# Altium schematic sheet BodySoftSnake.SchDoc
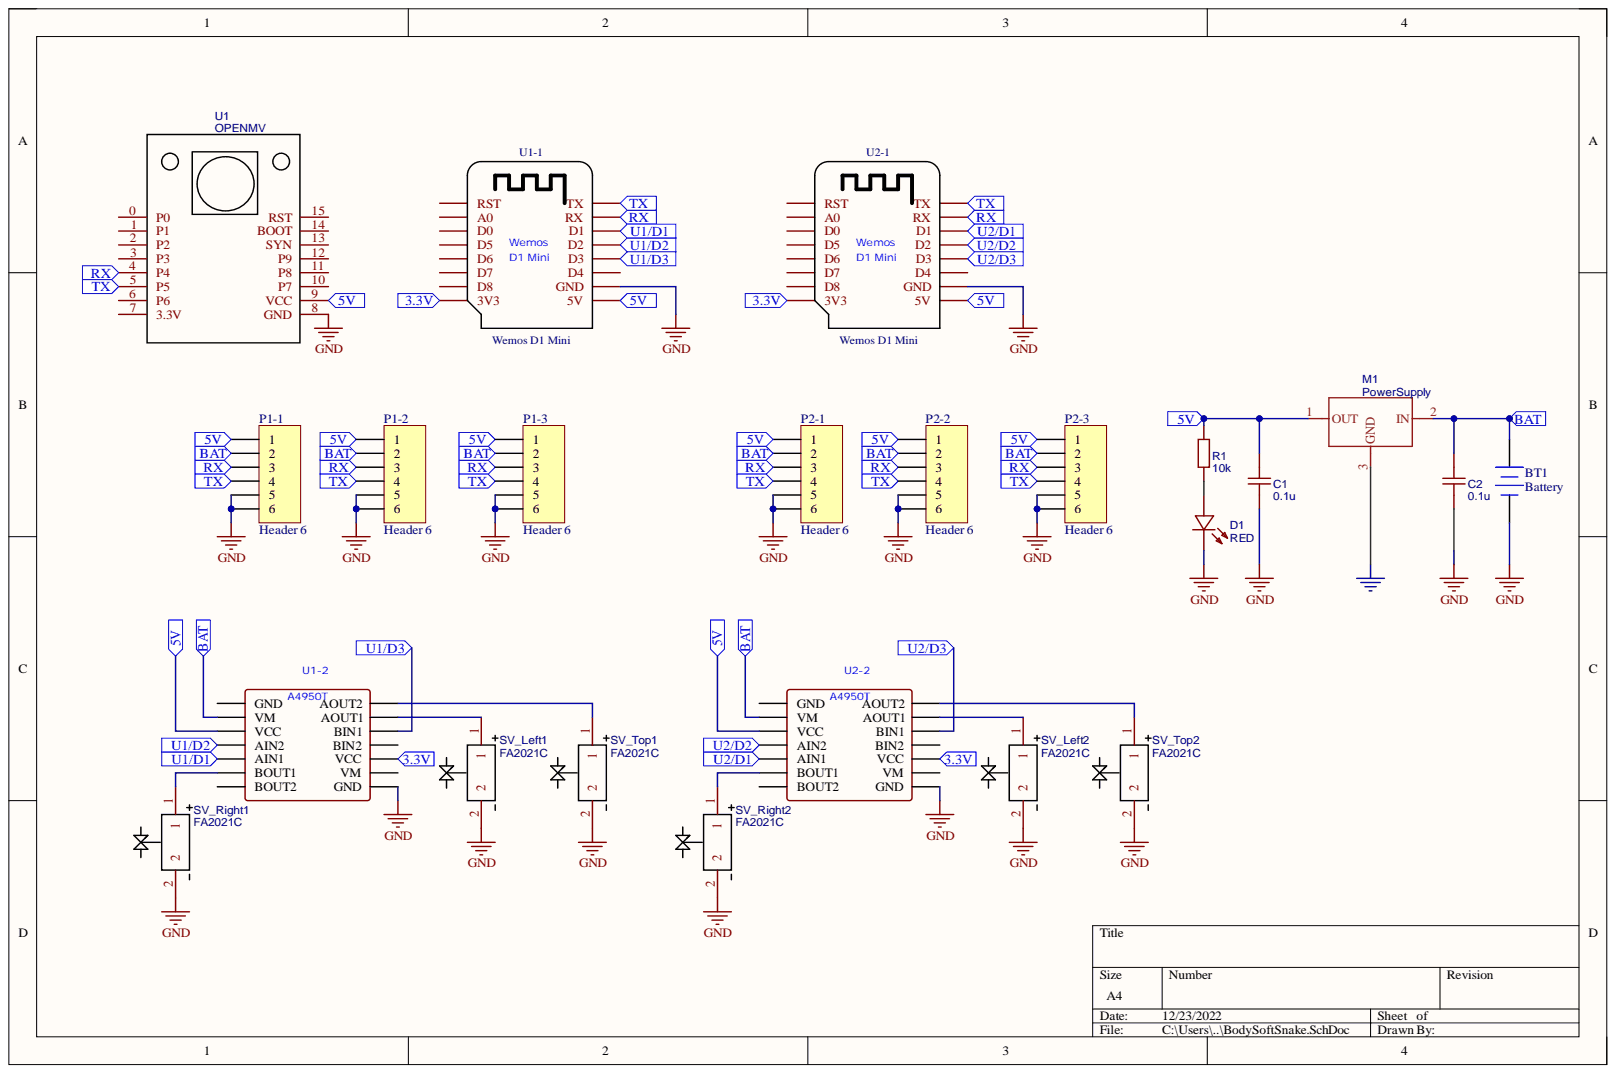
[diagram p0: BodySoftSnake.SchDoc]

## Parts
BT1  Battery  [BAT-2]
C1  0.1u  [C-0603]
C2  0.1u  [C-0603]
D1  RED  [L-0603]
M1  PowerSupply  [SEEED-TO-252]
P1-1  Header 6  [HDR1X6]
P1-2  Header 6  [HDR1X6]
P1-3  Header 6  [HDR1X6]
P2-1  Header 6  [HDR1X6]
P2-2  Header 6  [HDR1X6]
P2-3  Header 6  [HDR1X6]
R1  10k  [3-0603]
SV_Left1  FA2021C  [SOLENOID]
SV_Left2  FA2021C  [SOLENOID]
SV_Right1  FA2021C  [SOLENOID]
SV_Right2  FA2021C  [SOLENOID]
SV_Top1  FA2021C  [SOLENOID]
SV_Top2  FA2021C  [SOLENOID]
U1  OPENMV  [OPENMV]
U1-1  Wemos D1 Mini  [CUSTOM]
U1-2  A4950T  [A4950 DUAL DRIVER MODULE]
U2-1  Wemos D1 Mini  [CUSTOM]
U2-2  A4950T  [A4950 DUAL DRIVER MODULE]

## Ports
3.3V, 5V, BAT, RX, TX, U1/D1, U1/D2, U1/D3, U2/D1, U2/D2, U2/D3

## Nets
GND: BT1.2 C1.1 C2.1 D1.K M1.3 P1-1.5 P1-1.6 P1-2.5 P1-2.6 P1-3.5 P1-3.6 P2-1.5 P2-1.6 P2-2.5 P2-2.6 P2-3.5 P2-3.6 SV_Left1.2 SV_Left2.2 SV_Right1.2 SV_Right2.2 SV_Top1.2 SV_Top2.2 U1.8(GND) U1-1.GND U1-2.8(GND) U2-1.GND U2-2.8(GND)
3.3V: U1-1.3V3 U1-2.10(VCC) U2-1.3V3 U2-2.10(VCC) ; port 3.3V
5V: C1.2 M1.1 P1-1.1 P1-2.1 P1-3.1 P2-1.1 P2-2.1 P2-3.1 R1.2 U1.9(VCC) U1-1.5V U1-2.3(VCC) U2-1.5V U2-2.3(VCC) ; port 5V
BAT: BT1.1 C2.2 M1.2 P1-1.2 P1-2.2 P1-3.2 P2-1.2 P2-2.2 P2-3.2 U1-2.2(VM) U2-2.2(VM) ; port BAT
RX: P1-1.3 P1-2.3 P1-3.3 P2-1.3 P2-2.3 P2-3.3 U1.4(P4) U1-1.RX U2-1.RX ; port RX
TX: P1-1.4 P1-2.4 P1-3.4 P2-1.4 P2-2.4 P2-3.4 U1.5(P5) U1-1.TX U2-1.TX ; port TX
U1/D1: U1-1.D1 U1-2.5(AIN1) ; port U1/D1
U1/D2: U1-1.D2 U1-2.4(AIN2) ; port U1/D2
U1/D3: U1-1.D3 U1-2.12(BIN1) ; port U1/D3
U2/D1: U2-1.D1 U2-2.5(AIN1) ; port U2/D1
U2/D2: U2-1.D2 U2-2.4(AIN2) ; port U2/D2
U2/D3: U2-1.D3 U2-2.12(BIN1) ; port U2/D3
NetD1_A: D1.A R1.1
NetSV_Left1_1: SV_Left1.1 U1-2.13(AOUT1)
NetSV_Left2_1: SV_Left2.1 U2-2.13(AOUT1)
NetSV_Right1_1: SV_Right1.1 U1-2.6(BOUT1)
NetSV_Right2_1: SV_Right2.1 U2-2.6(BOUT1)
NetSV_Top1_1: SV_Top1.1 U1-2.14(AOUT2)
NetSV_Top2_1: SV_Top2.1 U2-2.14(AOUT2)

Not connected: U1 0(P0) 1(P1) 2(P2) 3(P3) 6(P6) 7(3.3V) 10(P7) 11(P8) 12(P9) 13(SYN) 14(BOOT) 15(RST); U1-1 A0 D0 D4 D5 D6 D7 D8 RESET(RST); U1-2 1(GND) 7(BOUT2) 9(VM) 11(BIN2); U2-1 A0 D0 D4 D5 D6 D7 D8 RESET(RST); U2-2 1(GND) 7(BOUT2) 9(VM) 11(BIN2)

## Library data of the parts
BT1 = Battery: Multicell Battery; Component Kind: Standard; LatestRevisionDate: 17-Jul-2002; LatestRevisionNote: Re-released for DXP Platform.; Library Name: Miscellaneous Devices.IntLib; PackageDescription: Battery; 2 Leads; PackageReference: BAT-2; Published: 24-Mar-1999; Publisher: Altium Limited
C1 C2 = 0.1u: nameAlias: Value(F); BOM_Manufacturer Part: ?; Component Kind: Standard; spicePre: C; spiceSymbolName: Capacitor
D1 = RED: nameAlias: Model; BOM_Manufacturer Part: ?; Component Kind: Standard; spicePre: D; spiceSymbolName: LED
M1 = LM7805: BOM_Manufacturer Part: CJ78M05; catid: 20; sourceId: VTNHZhztL; BOM_Supplier: SeeedStudio; BOM_Supplier Part: 310030100; Component Kind: Standard; spicePre: U; timeStamp: 1448004722
P1-1-P1-3 P2-1-P2-3 = Header 6: Header, 6-Pin; Component Kind: Standard; LatestRevisionDate: 17-Jul-2002; LatestRevisionNote: Re-released for DXP Platform.; Library Name: Miscellaneous Connectors.IntLib; Signal Integrity: Connector; Publisher: Altium Limited
R1 = 10k: nameAlias: Value(Ω); BOM_Manufacturer Part: ?; Component Kind: Standard; spicePre: R; spiceSymbolName: Resistor
SV_Left1 SV_Left2 SV_Right1 SV_Right2 SV_Top1 SV_Top2 = solenoid: Contributor: 397226A; Component Kind: Standard; spicePre: U; spiceSymbolName: solenoid
U1 = OPENMV: Contributor: zsq_123456; Component Kind: Standard; spicePre: U; spiceSymbolName: OPENMV
U1-2 U2-2 = A4950 DUAL MOTOR DRIVER MODULE: Component Kind: Standard
U1-1 U2-1 = Wemos D1 Mini: Component Kind: Standard
